# Altium schematic sheet MAIN.SchDoc(MAIN)
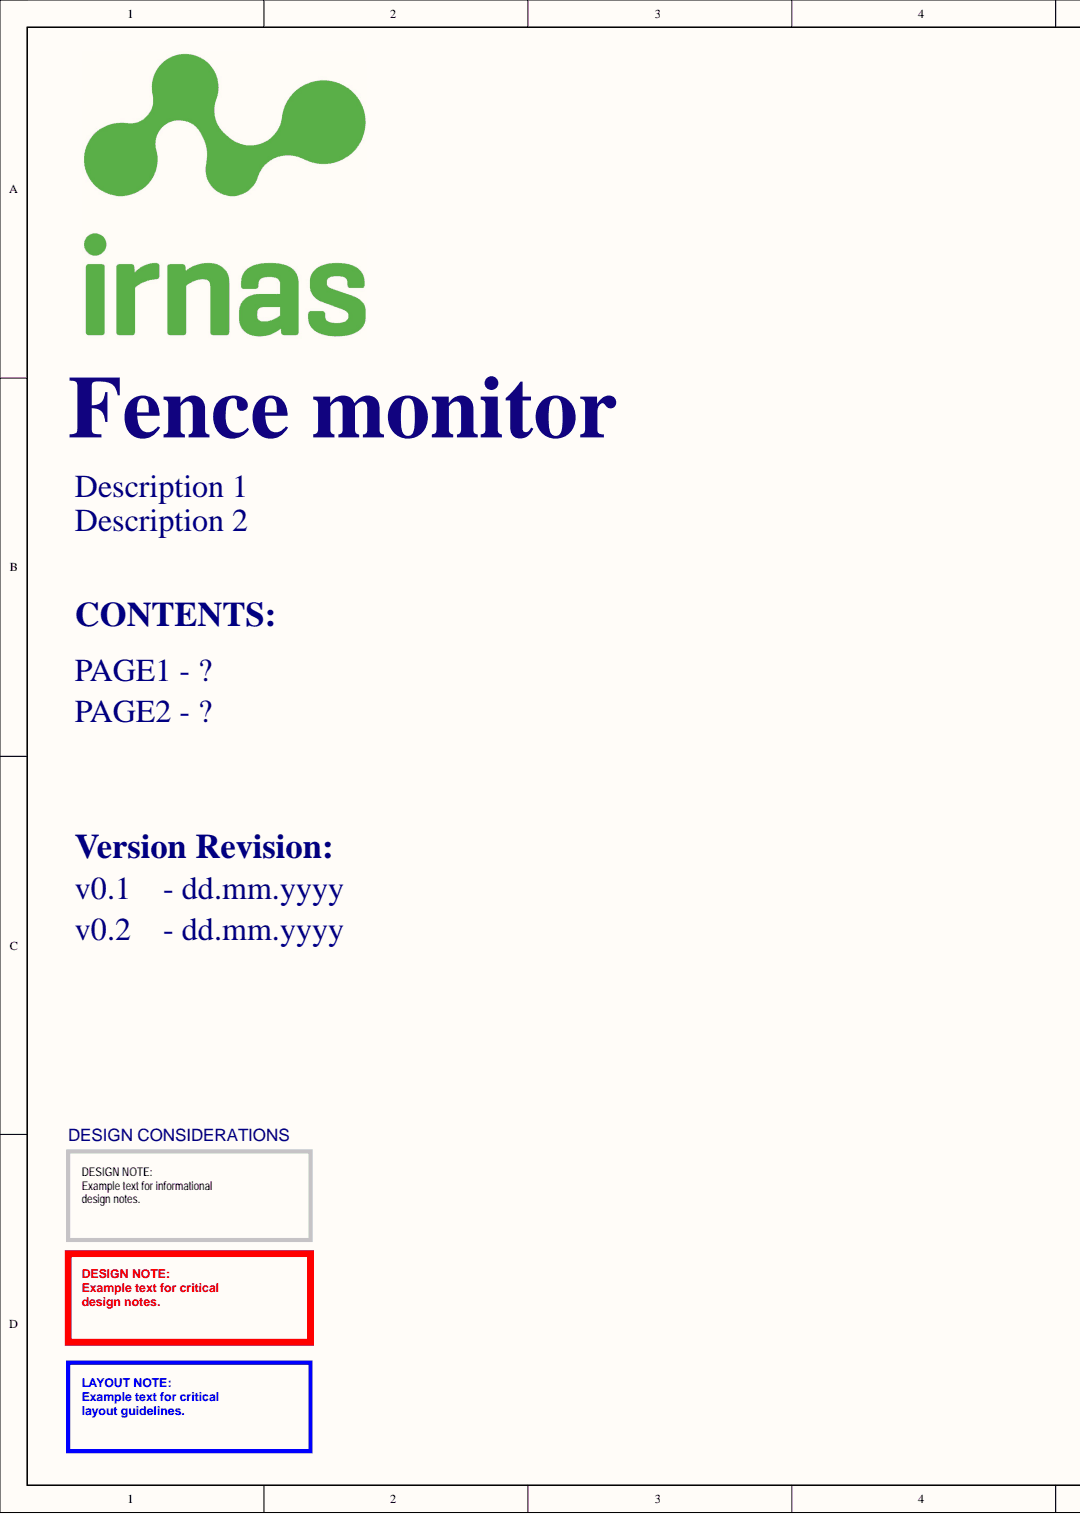
[diagram p0: MAIN.SchDoc, left]
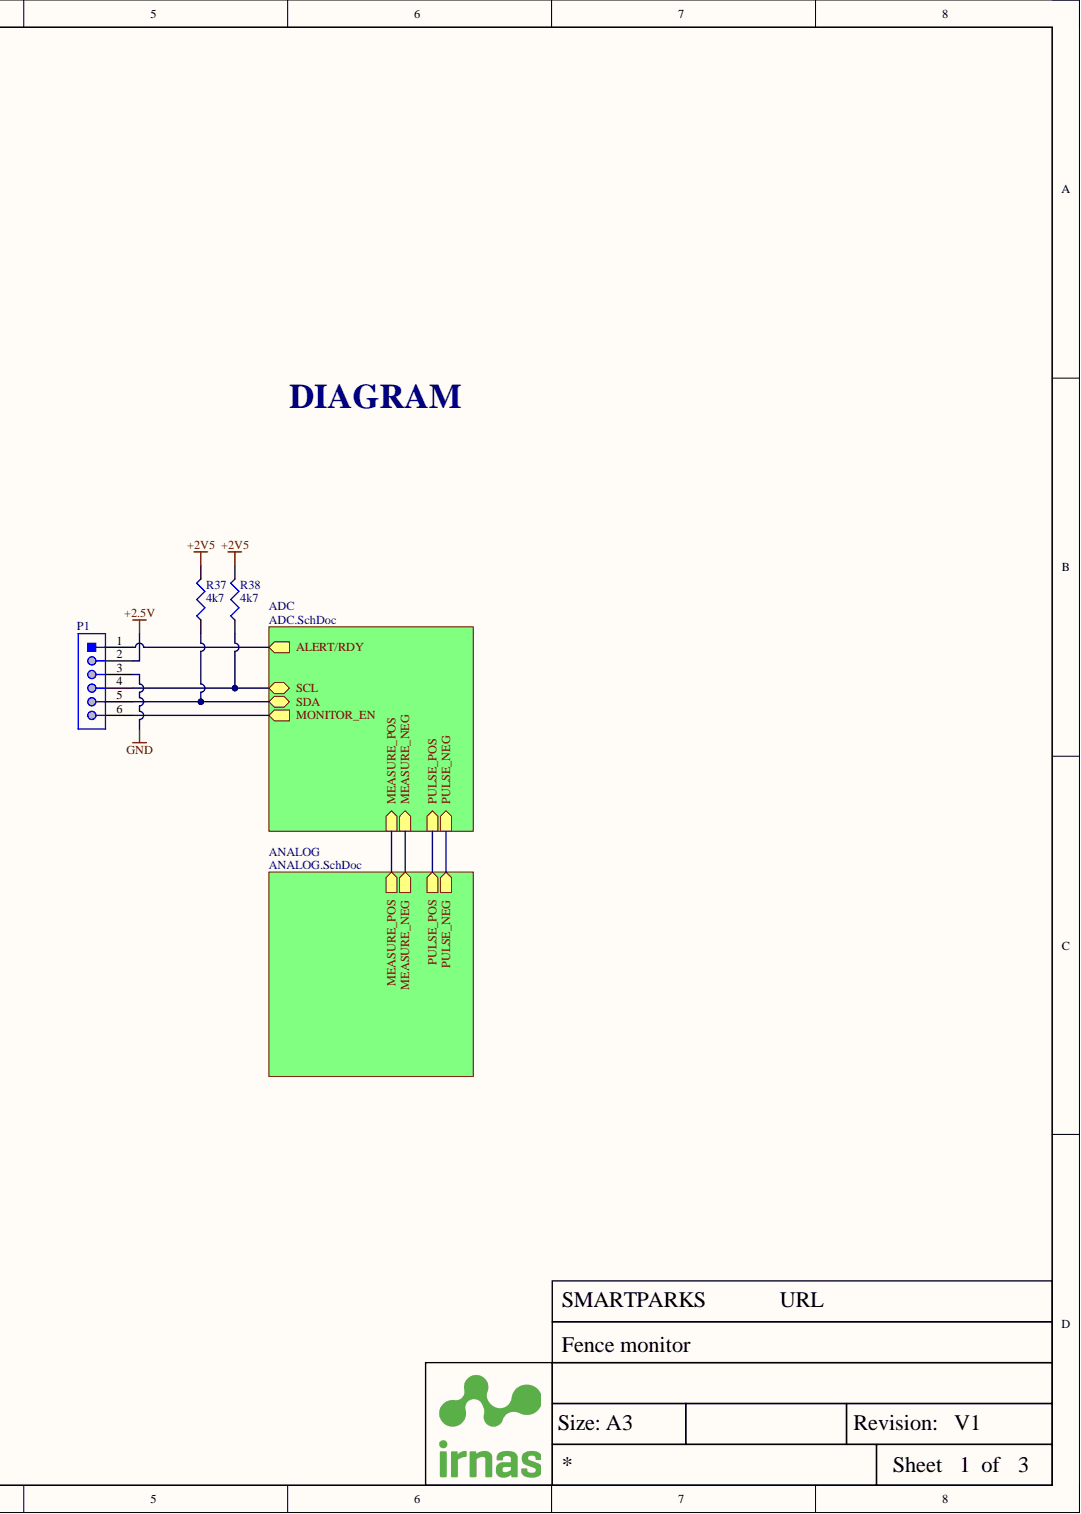
[diagram p1: MAIN.SchDoc, right]

## Parts
P1  IRNAS - LION_EXTENSION  [IRNAL_LION_ADDON]  (value not drawn)  (no BOM)
R37  4k7  [RES0402]
R38  4k7  [RES0402]

## Nets
+2.5V: P1.2
+2V5: R37.2 R38.2
GND: P1.3
NetP1_1: P1.1
NetP1_4: P1.4 R38.1
NetP1_5: P1.5 R37.1
NetP1_6: P1.6
MEASURE_NEG:
MEASURE_POS:
PULSE_NEG:
PULSE_POS:

## Library data of the parts
P1 = IRNAS - LION_EXTENSION: LION_EXTENSION; ComponentLink1Description: Manufacturer; ComponentLink1URL: http://www.we-online.de; ComponentLink2Description: Datasheet; ComponentLink2URL: http://katalog.we-online.de/em/datasheet/691305130004.pdf; Library Name: Connectors.SchLib
R37 R38 = R - 4k7/0402: 4.7 kohm, MCWR Series, 50 V; IRNAS Part No.: I-100003; Manufacturer: MULTICOMP; Manufacturer Part Number: MCMR06X4701FTL; Power Rating: 100; Product Range: MCMR Series; Resistance: 4.7; Resistance Tolerance: ± 1%; Resistor Case Style: 0603 [1608 Metric]; Resistor Element Material: Ceramic; SVHC: No SVHC (12-Jan-2017)<!--FANDB--><div class="features-and-benefits-container"><div class="features-and-benefits-description"><span class="features-benefits-value">The Multicomp MCMR series resistors are automotive grade, anti sulphured thick film chip resistor. The resistance range includes E24 and E96 decade values from 1ohm to 10Mohm. These resistors are constructed on top of a high grade ceramic body.</span></div><div class="features-and-benefits-list"><ul class="features-and-benefits-list-list"><li class="features-and-benefits-list-item">EIA 0402, 0603, 0805, 1206 chip sizes available</li><li class="features-and-benefits-list-item">High reliability and stability</li><li class="features-and-benefits-list-item">Automotive grade AEC-Q200 compliant</li><li class="features-and-benefits-list-item">Complaint with (IEC 60068-1) 55/155/56 climatic category</li><li class="features-and-benefits-list-item">Maximum operation voltage of 50V, 75V, 150V and 200V</li><li class="features-and-benefits-list-item">Power rating of 62.5mW, 100mW, 125mW and 250mW</li><li class="features-and-benefits-list-item">Available in ±1% and ±5% tolerance</li><li class="features-and-benefits-list-item">Temperature coefficient (TCR) of +200ppm/°C, +100ppm/°C and -200ppm/°C to +400ppm/°C</li><li class="features-and-benefits-list-item">Operating temperature range -55°C to 155; Temperature Coefficient: ± 100; Voltage Rating: 75; Automotive Qualification Standard: -; Component Kind: Standard; ComponentLink1Description: Datasheet; ComponentLink1URL: http://www.farnell.com/datasheets/2860681.pdf?_ga=2.106290502.431277253.1573729600-1373726713.1554121096&_gac=1.180096854.1571402529.CjwKCAjwxaXtBRBbEiwAPqPxcCedmxiKa04iNyErqeZ3YE_megEuFQhz7mFuJRxR0uEYBmhYeHxt1xoC4MkQAvD_BwE; Library Name: Passive.SchLib; Packaging: Cut Tape; Pricing 1: 10=0.0085, 100=0.0051, 500=0.0036, 1000=0.0028, 2500=0.0023 (GBP); RoHS: Y-EX; Stock 1: 218618; Supplier 1: Farnell; Supplier Part Number 1: 2447187
